# Altium schematic sheet USB-Socket.SchDoc(U_USB-Socket)
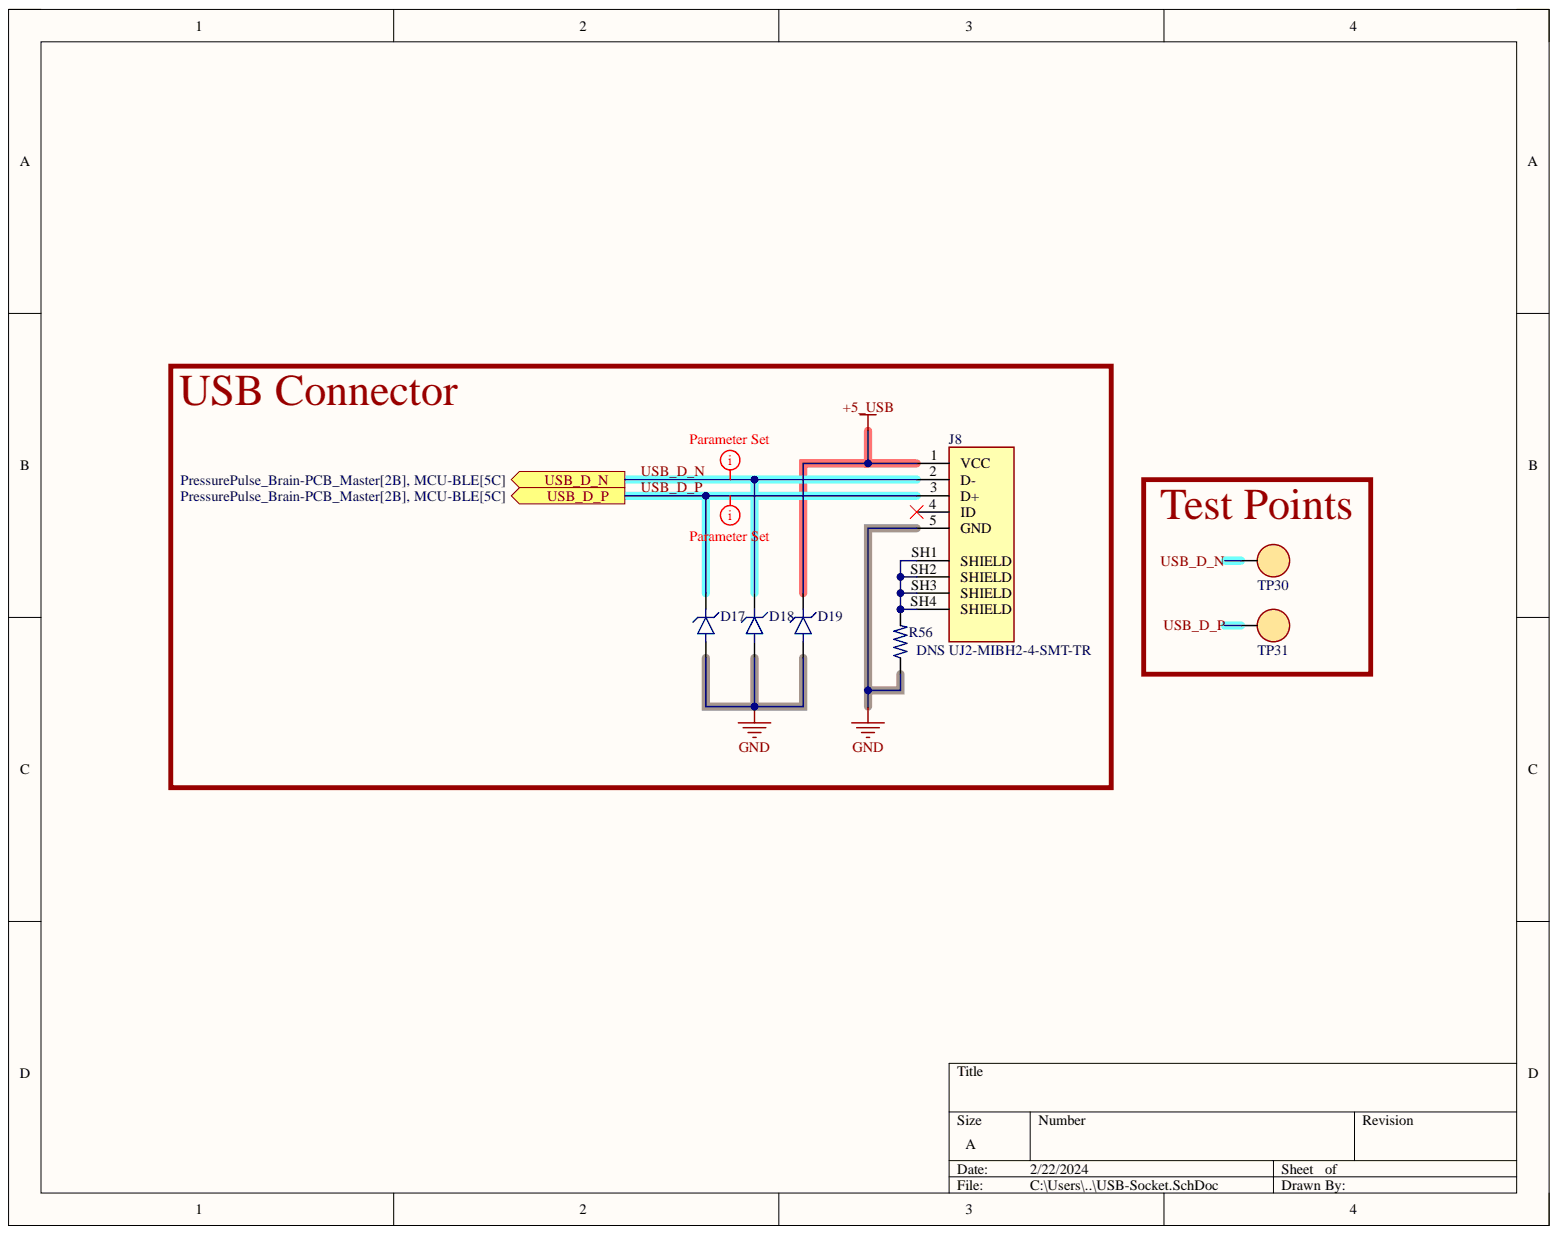
[diagram p0: USB-Socket.SchDoc]

## Parts
D17  RCLAMP0521P.TCT  [RCLAMP0521P.TCT]  (value not drawn)
D18  RCLAMP0521P.TCT  [RCLAMP0521P.TCT]  (value not drawn)
D19  RCLAMP0521P.TCT  [RCLAMP0521P.TCT]  (value not drawn)
J8  UJ2-MIBH2-4-SMT-TR  [USB MICRO JLC]
R56  value DNS  (hidden comment: RES)
TP30  RH-5019  [TP - RH-5019]  (value not drawn)
TP31  RH-5019  [TP - RH-5019]  (value not drawn)

## Ports
USB_D_N, USB_D_P

## Nets
+5_USB: D19.K J8.1(VCC)
GND: D17.A D18.A D19.A J8.5(GND) R56.1
USB_D_N: D18.K J8.2(D-) TP30.1 ; port USB_D_N
USB_D_P: D17.K J8.3(D+) TP31.1 ; port USB_D_P
NetJ8_4: J8.4(ID)
NetJ8_SH1: J8.SH1(SHIELD) J8.SH2(SHIELD) J8.SH3(SHIELD) J8.SH4(SHIELD) R56.2

## Library data of the parts
D17-D19 = TVS DIODE: 25V Clamp 4A (8/20µs) Ipp Tvs Diode Surface Mount SLP1006P2; MFR: Semtech Corporation; Type: SMD; Component Kind: Standard; Library Name: PressurePulse.SchLib; MFR PN: RCLAMP0521P.TCT; Value: RCLAMP0521P.TCT
J8 = Micro USB Port: Micro B, USB 2.0, 1.8 A, Right Angle, Surface Mount (SMT), USB Receptacle; MFR: CUI Devices; Component Kind: Standard; Library Name: PressurePulse.SchLib; Value: UJ2-MIBH2-4-SMT-TR
R56 = RES: Resistor; Component Kind: Standard; Library Name: PressurePulse.SchLib
TP30 TP31 = TEST POINT: MFR: ronghe; Component Kind: Standard; JLCPCB PN: C5199800; Library Name: PressurePulse.SchLib; MFR PN: RH-5019; Value: RH-5019
